# Altium schematic sheet shake-it-off-v1-main.SchDoc(shake-it-off-v1-main)
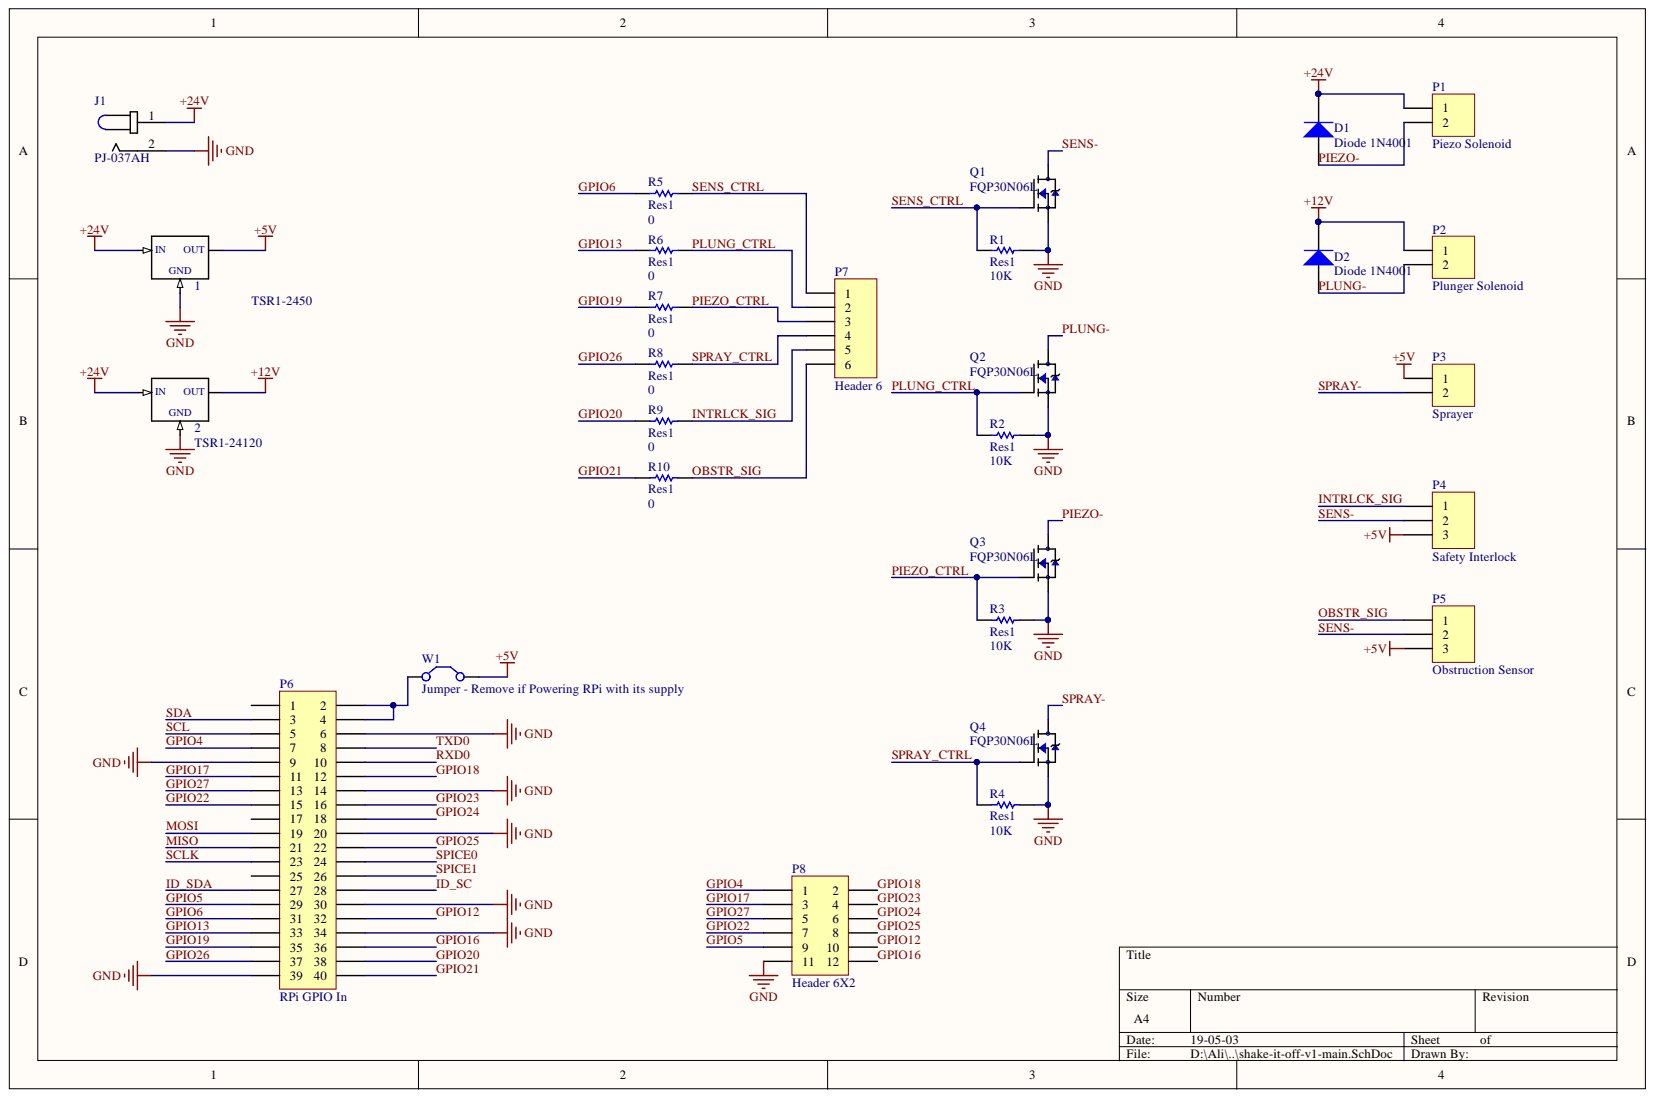
[diagram p0: shake-it-off-v1-main.SchDoc]

## Parts
1  TSR1-2450  [*TSR1-2450]
2  TSR1-24120  [*TSR1-2450]
D1  Diode 1N4001  [DO-41]
D2  Diode 1N4001  [DO-41]
J1  PJ-037AH  [*CUI_PJ-037AH]
P1  Piezo Solenoid  [HDR1X2]
P2  Plunger Solenoid  [HDR1X2]
P3  Sprayer  [HDR1X2]
P4  Safety Interlock  [HDR1X3]
P5  Obstruction Sensor  [HDR1X3]
P6  RPi GPIO In  [HDR2X20]
P7  Header 6  [HDR1X6]
P8  Header 6X2  [HDR2X6]
Q1  FQP30N06L  [*TO220V]
Q2  FQP30N06L  [*TO220V]
Q3  FQP30N06L  [*TO220V]
Q4  FQP30N06L  [*TO220V]
R1  Res1  value 10K  [0603]
R2  Res1  value 10K  [0603]
R3  Res1  value 10K  [0603]
R4  Res1  value 10K  [0603]
R5  Res1  [0603]
R6  Res1  value 0  [0603]
R7  Res1  value 0  [0603]
R8  Res1  value 0  [0603]
R9  Res1  value 0  [0603]
R10  Res1  value 0  [0603]
W1  Jumper - Remove if Powering RPi with its supply  [HDR1X2]

## Nets
+5V: 1.3 P3.1 P4.3 P5.3 W1.2
+12V: 2.3 D2.2 P2.1
+24V: 1.1 2.1 D1.2 J1.1 P1.1
GND: 1.2 2.2 J1.2 P6.6 P6.9 P6.14 P6.20 P6.30 P6.34 P6.39 P8.11 Q1.3 Q2.3 Q3.3 Q4.3 R1.1 R2.1 R3.1 R4.1
GPIO4: P6.7 P8.1
GPIO5: P6.29 P8.9
GPIO6: P6.31 R5.1
GPIO12: P6.32 P8.10
GPIO13: P6.33 R6.1
GPIO16: P6.36 P8.12
GPIO17: P6.11 P8.3
GPIO18: P6.12 P8.2
GPIO19: P6.35 R7.1
GPIO20: P6.38 R9.1
GPIO21: P6.40 R10.1
GPIO22: P6.15 P8.7
GPIO23: P6.16 P8.4
GPIO24: P6.18 P8.6
GPIO25: P6.22 P8.8
GPIO26: P6.37 R8.1
GPIO27: P6.13 P8.5
ID_SC: P6.28
ID_SDA: P6.27
INTRLCK_SIG: P4.1 P7.5 R9.2
MISO: P6.21
MOSI: P6.19
OBSTR_SIG: P5.1 P7.6 R10.2
PIEZO-: D1.1 P1.2 Q3.2
PIEZO_CTRL: P7.3 Q3.1 R3.2 R7.2
PLUNG-: D2.1 P2.2 Q2.2
PLUNG_CTRL: P7.2 Q2.1 R2.2 R6.2
RXD0: P6.10
SCL: P6.5
SCLK: P6.23
SDA: P6.3
SENS-: P4.2 P5.2 Q1.2
SENS_CTRL: P7.1 Q1.1 R1.2 R5.2
SPICE0: P6.24
SPICE1: P6.26
SPRAY-: P3.2 Q4.2
SPRAY_CTRL: P7.4 Q4.1 R4.2 R8.2
TXD0: P6.8
NetP6_2: P6.2 P6.4 W1.1

Not connected: P6 1 17 25

## Library data of the parts
1 2 = TSR1-2450: Converter: DC/DC; Uin: 6.5Ã·36V; 5VDC; Iout: 1A; SIP3; Eff; MF: Traco Power; SnapEDA_Link: https://www.snapeda.com/parts/TSR%201-2450/Traco%20Power/view-part/?ref=snap; Price: None; Package: SIP-3 Tracopower; MP: TSR 1-2450; Library Name: TSR1-2450.SchLib; ComponentLink1URL: https://www.digikey.ca/product-detail/en/traco-power/TSR-1-2450/1951-2747-ND/9383780; ComponentLink1Description: Digikey - TSR-1-2450; Component Kind: Standard; Availability: Unavailable
D1 D2 = Diode 1N4001: 1 Amp General Purpose Rectifier; Simulation: 1N4001; Signal Integrity: 1N4001; Published: 8-Jun-2000; PackageVersion: Aug-1999; PackageReference: DO-41; PackageDescription: Diode, Axial; Body 5.2 x 2.7 mm (LxDia. max), Lead Dia. 0.86 mm (max); Library Name: Miscellaneous Devices.IntLib; LatestRevisionNote: Re-released for DXP Platform.; LatestRevisionDate: 17-Jul-2002; DatasheetVersion: 1998; ComponentLink2URL: http://www.fairchildsemi.com/ds/1N%2F1N4001.pdf; ComponentLink2Description: Datasheet; ComponentLink1URL: http://www.fairchildsemi.com/; ComponentLink1Description: Manufacturer Link; Component Kind: Standard; Code_JEDEC: DO-204-AL; Publisher: Altium Limited
J1 = PJ-037AH: 2.0 mm Center Pin, 5.0 A, Right Angle, Through Hole, Dc Power Jack Connector; MF: CUI Inc.; SnapEDA_Link: https://www.snapeda.com/parts/PJ-037AH/CUI%20Inc./view-part/?ref=snap; Package: None; MP: PJ-037AH; Library Name: PJ-037AH.SchLib; CUI_purchase_URL: https://www.cui.com/product/interconnect/dc-power-connectors/jacks/2.0-mm-center-pin/pj-037ah?utm_source=snapeda.com&utm_medium=referral&utm_campaign=snapedaBOM; ComponentLink1URL: https://www.digikey.com/product-detail/en/cui-inc/PJ-037AH/CP-037AH-ND/1644547; ComponentLink1Description: Digikey; Component Kind: Standard
P1-P3 = Header 2: Header, 2-Pin; Signal Integrity: Connector; Library Name: Miscellaneous Connectors.IntLib; LatestRevisionNote: Re-released for DXP Platform.; LatestRevisionDate: 17-Jul-2002; Component Kind: Standard; Publisher: Altium Limited
P4 P5 = Header 3: Header, 3-Pin; Signal Integrity: Connector; Library Name: Miscellaneous Connectors.IntLib; LatestRevisionNote: Re-released for DXP Platform.; LatestRevisionDate: 17-Jul-2002; Component Kind: Standard; Publisher: Altium Limited
P6 = Header 20X2: Header, 20-Pin, Dual row; Signal Integrity: Connector; Library Name: Miscellaneous Connectors.IntLib; LatestRevisionNote: Re-released for DXP Platform.; LatestRevisionDate: 17-Jul-2002; Component Kind: Standard; Publisher: Altium Limited
P7 = Header 6: Header, 6-Pin; Signal Integrity: Connector; Library Name: Miscellaneous Connectors.IntLib; LatestRevisionNote: Re-released for DXP Platform.; LatestRevisionDate: 17-Jul-2002; Component Kind: Standard; Publisher: Altium Limited
P8 = Header 6X2: Header, 6-Pin, Dual row; Signal Integrity: Connector; Library Name: Miscellaneous Connectors.IntLib; LatestRevisionNote: Re-released for DXP Platform.; LatestRevisionDate: 17-Jul-2002; Component Kind: Standard; Publisher: Altium Limited
Q1-Q4 = FQP30N06L: MOSFET Transistor, N Channel, 32 A, 60 V, 27 mohm, 10 V, 2.5 V; MF: ON Semiconductor; SnapEDA_Link: https://www.snapeda.com/parts/FQP30N06L/ON%20Semiconductor/view-part/?ref=snap; Price: None; Package: TO-220 ON Semiconductor; MP: FQP30N06L; Library Name: FQP30N06L.IntLib; Component Kind: Standard; Availability: Unavailable
R1-R4 R6-R10 = Res1: Resistor; Simulation: RESISTOR; Signal Integrity: Res; Published: 8-Jun-2000; PackageReference: AXIAL-0.3; PackageDescription: Axial Device, Thru-Hole; 2 Leads; 0.3 in Pin Spacing; Library Name: Miscellaneous Devices.IntLib; LatestRevisionNote: Re-released for DXP Platform.; LatestRevisionDate: 17-Jul-2002; Component Kind: Standard; Publisher: Altium Limited
R5 = Res1: Resistor; Value: 0; Simulation: RESISTOR; Signal Integrity: Res; Published: 8-Jun-2000; PackageReference: AXIAL-0.3; PackageDescription: Axial Device, Thru-Hole; 2 Leads; 0.3 in Pin Spacing; Library Name: Miscellaneous Devices.IntLib; LatestRevisionNote: Re-released for DXP Platform.; LatestRevisionDate: 17-Jul-2002; Component Kind: Standard; Publisher: Altium Limited
W1 = Jumper: Jumper Wire; Published: 24-Mar-1999; PackageReference: RAD-0.2; PackageDescription: Radial Cap, Thru-Hole; 2 Leads; 0.2 in Pin Spacing; Library Name: Miscellaneous Devices.IntLib; LatestRevisionNote: Re-released for DXP Platform.; LatestRevisionDate: 17-Jul-2002; Component Kind: Standard; Publisher: Altium Limited
